# Altium schematic sheet dbesc 1.0.SchDoc
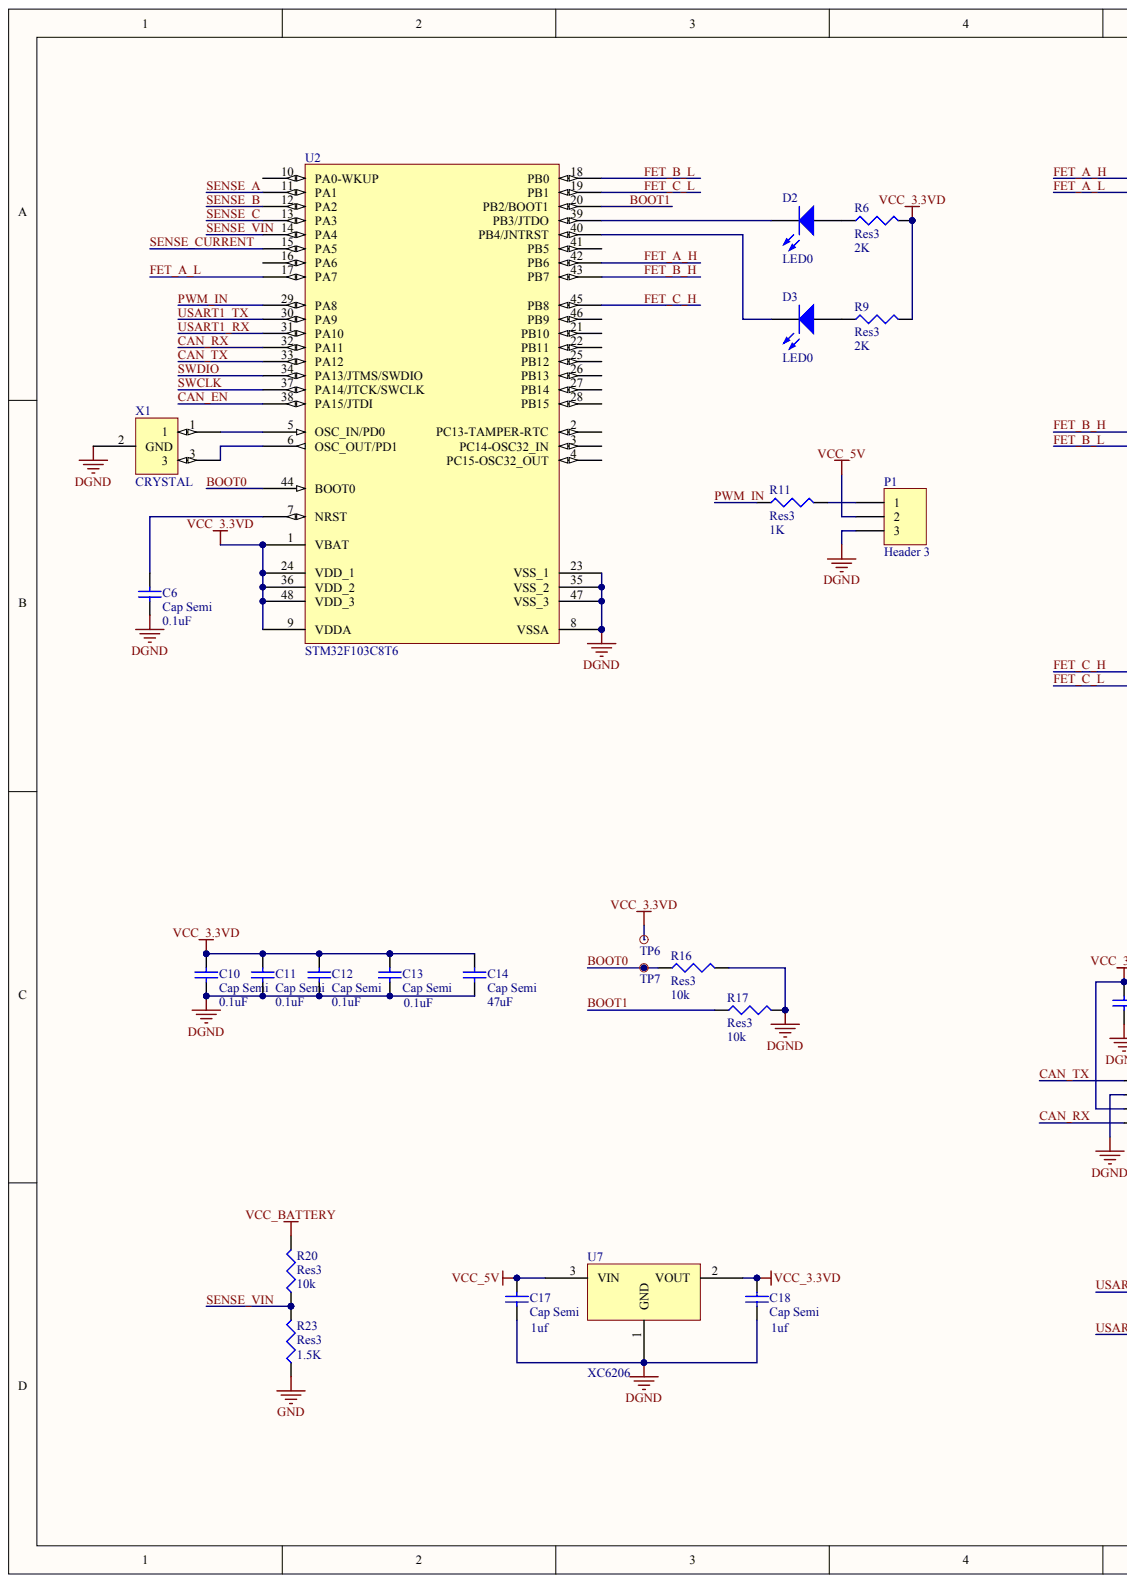
[diagram p0: dbesc 1.0.SchDoc, left]
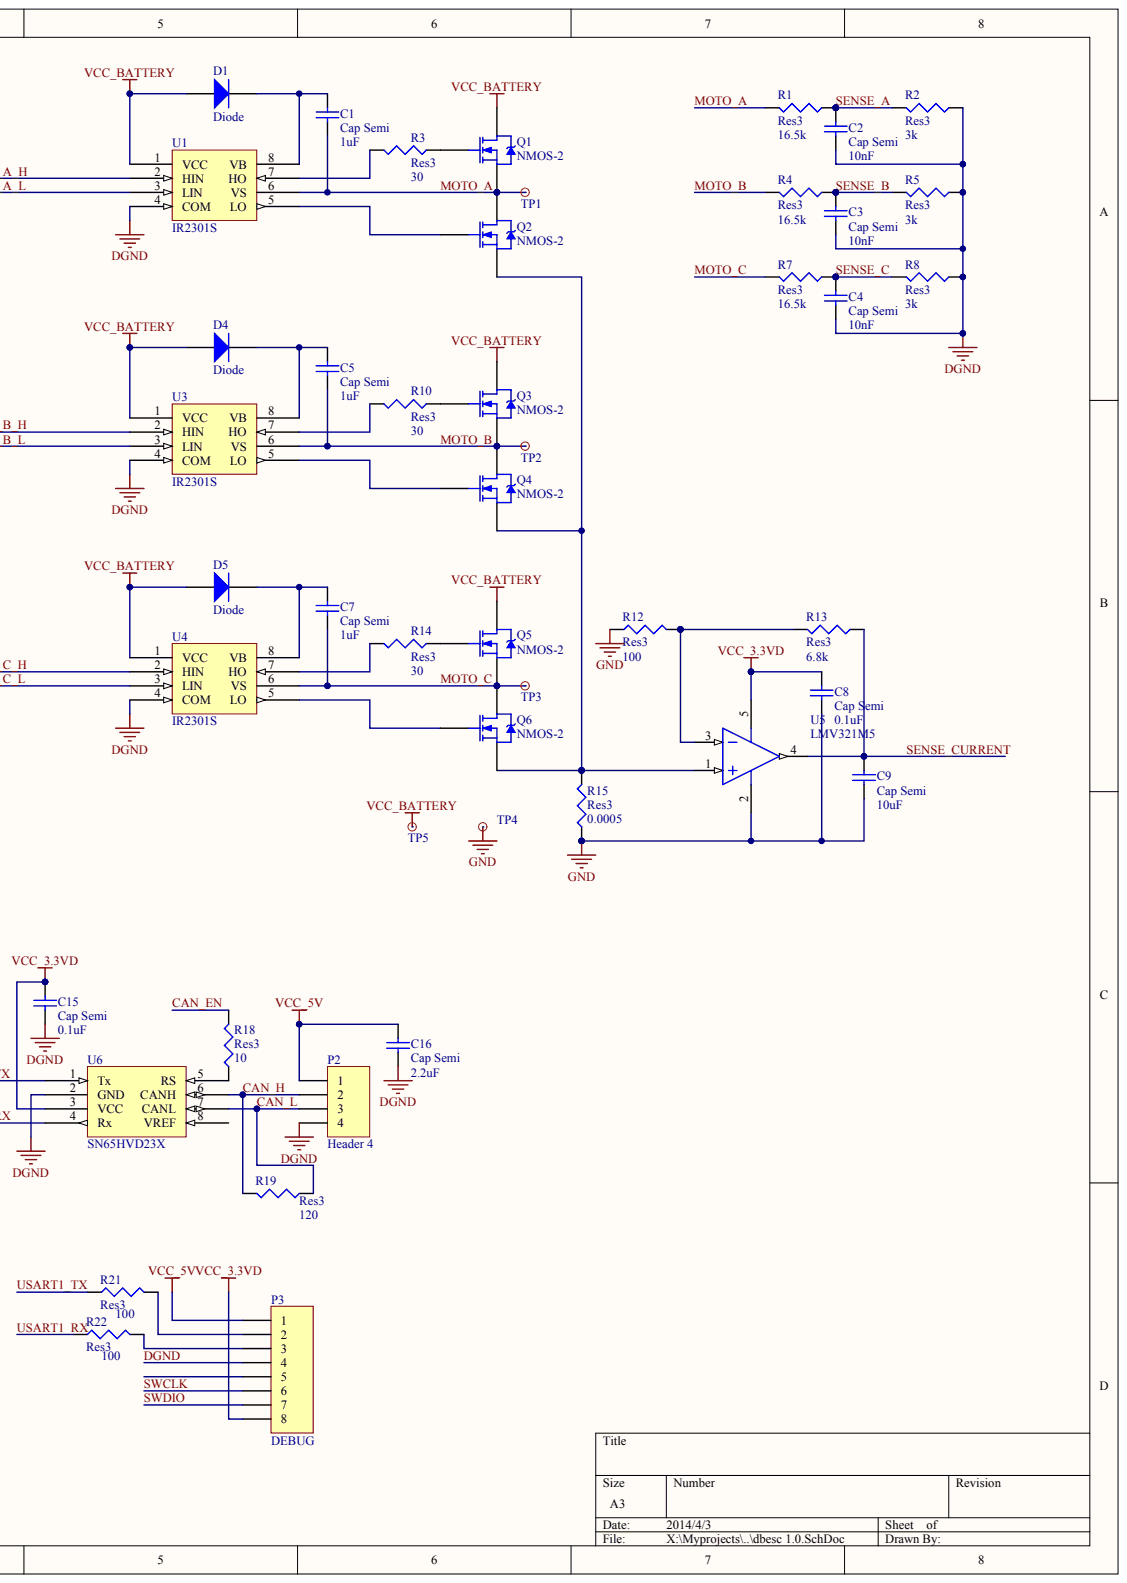
[diagram p1: dbesc 1.0.SchDoc, right]

## Parts
C1  Cap Semi  value 1uF
C2  Cap Semi  value 10nF
C3  Cap Semi  value 10nF
C4  Cap Semi  value 10nF
C5  Cap Semi  value 1uF
C6  Cap Semi  value 0.1uF
C7  Cap Semi  value 1uF
C8  Cap Semi  value 0.1uF
C9  Cap Semi  value 10uF
C10  Cap Semi  value 0.1uF
C11  Cap Semi  value 0.1uF
C12  Cap Semi  value 0.1uF
C13  Cap Semi  value 0.1uF
C14  Cap Semi  value 47uF
C15  Cap Semi  value 0.1uF
C16  Cap Semi  value 2.2uF
C17  Cap Semi  value 1uf
C18  Cap Semi  value 1uf
D1  Diode
D2  LED0
D3  LED0
D4  Diode
D5  Diode
P1  Header 3
P2  Header 4
P3  DEBUG
Q1  NMOS-2
Q2  NMOS-2
Q3  NMOS-2
Q4  NMOS-2
Q5  NMOS-2
Q6  NMOS-2
R1  Res3  value 16.5k
R2  Res3  value 3k
R3  Res3  value 30
R4  Res3  value 16.5k
R5  Res3  value 3k
R6  Res3  value 2K
R7  Res3  value 16.5k
R8  Res3  value 3k
R9  Res3  value 2K
R10  Res3  value 30
R11  Res3  value 1K
R12  Res3  value 100
R13  Res3  value 6.8k
R14  Res3  value 30
R15  Res3  value 0.0005
R16  Res3  value 10k
R17  Res3  value 10k
R18  Res3  value 10
R19  Res3  value 120
R20  Res3  value 10k
R21  Res3  value 100
R22  Res3  value 100
R23  Res3  value 1.5K
TP1  TestPoint  (value not drawn)
TP2  TestPoint  (value not drawn)
TP3  TestPoint  (value not drawn)
TP4  TestPoint  (value not drawn)
TP5  TestPoint  (value not drawn)
TP6  TestPoint  (value not drawn)
TP7  TestPoint  (value not drawn)
U1  IR2301S
U2  STM32F103C8T6
U3  IR2301S
U4  IR2301S
U5  LMV321M5
U6  SN65HVD23X
U7  XC6206
X1  CRYSTAL

## Nets
GND: C8.2 C9.2 R12.1 R15.1 R23.1 TP4.1 U5.2
VCC_3.3VD: C8.1 C10.2 C11.2 C12.2 C13.2 C14.2 C15.2 C18.2 P3.8 R6.2 R9.2 TP6.1 U2.1(VBAT) U2.9(VDDA) U2.24(VDD_1) U2.36(VDD_2) U2.48(VDD_3) U5.5 U6.3(VCC) U7.2
VCC_5V: C16.1 C17.2 P1.2 P2.1 P3.1 U7.3
VCC_BATTERY: D1.1 D4.1 D5.1 Q1.2 Q3.2 Q5.2 R20.2 TP5.1 U1.1(VCC) U3.1(VCC) U4.1(VCC)
BOOT0: R16.1 TP7.1 U2.44(BOOT0)
BOOT1: R17.1 U2.20(PB2/BOOT1)
CAN_EN: R18.2 U2.38(PA15/JTDI)
CAN_H: P2.2 R19.2 U6.6(CANH)
CAN_L: P2.3 R19.1 U6.7(CANL)
CAN_RX: U2.32(PA11) U6.4(Rx)
CAN_TX: U2.33(PA12) U6.1(Tx)
DGND: C2.2 C3.2 C4.2 C6.2 C10.1 C11.1 C12.1 C13.1 C14.1 C15.1 C16.2 C17.1 C18.1 P1.3 P2.4 P3.4 R2.1 R5.1 R8.1 R16.2 R17.2 U1.4(COM) U2.8(VSSA) U2.23(VSS_1) U2.35(VSS_2) U2.47(VSS_3) U3.4(COM) U4.4(COM) U6.2(GND) U7.1 X1.2
FET_A_H: U1.2(HIN) U2.42(PB6)
FET_A_L: U1.3(LIN) U2.17(PA7)
FET_B_H: U2.43(PB7) U3.2(HIN)
FET_B_L: U2.18(PB0) U3.3(LIN)
FET_C_H: U2.45(PB8) U4.2(HIN)
FET_C_L: U2.19(PB1) U4.3(LIN)
MOTO_A: C1.2 Q1.3 Q2.2 R1.2 TP1.1 U1.6(VS)
MOTO_B: C5.2 Q3.3 Q4.2 R4.2 TP2.1 U3.6(VS)
MOTO_C: C7.2 Q5.3 Q6.2 R7.2 TP3.1 U4.6(VS)
PWM_IN: R11.1 U2.29(PA8)
SENSE_A: C2.1 R1.1 R2.2 U2.11(PA1)
SENSE_B: C3.1 R4.1 R5.2 U2.12(PA2)
SENSE_C: C4.1 R7.1 R8.2 U2.13(PA3)
SENSE_CURRENT: C9.1 R13.1 U2.15(PA5) U5.4
SENSE_VIN: R20.1 R23.2 U2.14(PA4)
SWCLK: P3.6 U2.37(PA14/JTCK/SWCLK)
SWDIO: P3.7 U2.34(PA13/JTMS/SWDIO)
USART1_RX: R22.1 U2.31(PA10)
USART1_TX: R21.1 U2.30(PA9)
NetC1_1: C1.1 D1.2 U1.8(VB)
NetC5_1: C5.1 D4.2 U3.8(VB)
NetC6_1: C6.1 U2.7(NRST)
NetC7_1: C7.1 D5.2 U4.8(VB)
NetD2_1: D2.1 R6.1
NetD2_2: D2.2 U2.39(PB3/JTDO)
NetD3_1: D3.1 R9.1
NetD3_2: D3.2 U2.40(PB4/JNTRST)
NetP1_1: P1.1 R11.2
NetP3_2: P3.2 R21.2
NetP3_3: P3.3 R22.2
NetQ1_1: Q1.1 R3.1
NetQ2_1: Q2.1 U1.5(LO)
NetQ2_3: Q2.3 Q4.3 Q6.3 R15.2 U5.1
NetQ3_1: Q3.1 R10.1
NetQ4_1: Q4.1 U3.5(LO)
NetQ5_1: Q5.1 R14.1
NetQ6_1: Q6.1 U4.5(LO)
NetR3_2: R3.2 U1.7(HO)
NetR10_2: R10.2 U3.7(HO)
NetR12_2: R12.2 R13.2 U5.3
NetR14_2: R14.2 U4.7(HO)
NetR18_1: R18.1 U6.5(RS)
NetU2_5: U2.5(OSC_IN/PD0) X1.1
NetU2_6: U2.6(OSC_OUT/PD1) X1.3

Not connected: P3 5; U2 2(PC13-TAMPER-RTC) 3(PC14-OSC32_IN) 4(PC15-OSC32_OUT) 10(PA0-WKUP) 16(PA6) 21(PB10) 22(PB11) 25(PB12) 26(PB13) 27(PB14) 28(PB15) 41(PB5) 46(PB9); U6 8(VREF)

## Library data of the parts
Q1-Q6 = (no symbol name): Note: Pin 2 is electrically connected to pin 4.; Published: 8-Jun-2000; LatestRevisionDate: 17-Jul-2002; LatestRevisionNote: Re-released for DXP Platform.; DatasheetVersion: PD-9.814; PackageVersion: Feb-1997; PackageReference: TO-220AB; PackageDescription: TO, Thru-Hole, Vertical, Heatsink Mounted; 3 In-Line Leads; Pitch 2.54 mm; ComponentLink1Description: Manufacturer Link; ComponentLink1URL: http://www.irf.com/; Publisher: Altium Limited
R6 R9 = (no symbol name): Value: 2K; Published: 8-Jun-2000; LatestRevisionDate: 29-May-2009; LatestRevisionNote: IPC-7351 Footprint Added.; PackageReference: J1-0603; PackageDescription: Chip Resistor; Publisher: Altium Limited
R13 = (no symbol name): Value: 6.8k; Published: 8-Jun-2000; LatestRevisionDate: 29-May-2009; LatestRevisionNote: IPC-7351 Footprint Added.; PackageReference: J1-0603; PackageDescription: Chip Resistor; Publisher: Altium Limited
U5 = (no symbol name): Manufacturer: National Semiconductor; CompType: Amplifiers; PackageTopMarking: A13*XTT; PowerWise: N; Published: 4-Dec-2008; LatestRevisionDate: 7-Apr-2009; LatestRevisionNote: Sch pinout corrected.; DatasheetVersion: Jan-2009; PackageVersion: revD, Aug-2008; PackageReference: MF05A; PackageDescription: Molded SOT-23, .115 x .063 x .040 in Body, 5 Lead, .0375 in Pitch; PartNumber: LMV321M5; RoHS: O; PinCount: 5; DigiKeyPartNumber: LMV321M5CT-ND; Code_IPC: SOT23-5; ComponentLink1Description: LMV321 Datasheet; ComponentLink1URL: http://www.national.com/ds/LM/LMV321.pdf; ComponentLink2Description: LMV321 Web Page; ComponentLink2URL: http://www.national.com/pf/LM/LMV321.html; ComponentLink3Description: MF05A Package Page; ComponentLink3URL: http://www.national.com/packaging/folders/mf05a.html; Publisher: Altium Limited
C1-C18 D1-D5 P1-P3 R1-R5 R7 R8 R10-R12 R14-R23 TP1-TP7 U1-U4 U6 U7 X1 = (no symbol name)
  C1-C18: Published: 8-Jun-2000; LatestRevisionDate: 29-May-2009; LatestRevisionNote: IPC-7351 Footprint Added.; PackageReference: C1206; PackageDescription: Chip Capacitor
  D1 D4 D5: Published: 8-Jun-2000; LatestRevisionDate: 17-Jul-2002; LatestRevisionNote: Re-released for DXP Platform.; PackageVersion: Sep-1996; PackageReference: SMC; PackageDescription: DO-214-AB/SMC; 2 C-Bend Leads; Body 7.9 x 5.9 mm, inc. leads (LxW); Code_JEDEC: DO-214-AB
  D2 D3: Published: 8-Jun-2000; LatestRevisionDate: 08-Jul-2005; LatestRevisionNote: Mech Layer 1 removed.; PackageReference: LED-0; PackageDescription: LED; 2 Leads
  P1-P3: LatestRevisionDate: 17-Jul-2002; LatestRevisionNote: Re-released for DXP Platform.
  R1-R5 R7 R8 R10-R12 R14-R23: Published: 8-Jun-2000; LatestRevisionDate: 29-May-2009; LatestRevisionNote: IPC-7351 Footprint Added.; PackageReference: J1-0603; PackageDescription: Chip Resistor
  U2: Published: 30-May-2008; DatasheetVersion: rev6, Mar-2008; PackageVersion: Mar-2008; PackageReference: LQFP48; PackageDescription: 48-Pin Low-Profile Quad Flat Pack, 7 x 7 mm Body, 0.5 mm Pitch (LQFP48); ComponentLink1Description: Manufacturer Link; ComponentLink1URL: www.st.com/stm32; ComponentLink2Description: Datasheet; ComponentLink2URL: http://www.st.com/stonline/products/literature/ds/13587.pdf
  C1-C18 D1-D5 P1-P3 R1-R5 R7 R8 R10-R12 R14-R23 U2: Publisher: Altium Limited
